# Altium schematic sheet MBoard_RelayStack.SchDoc
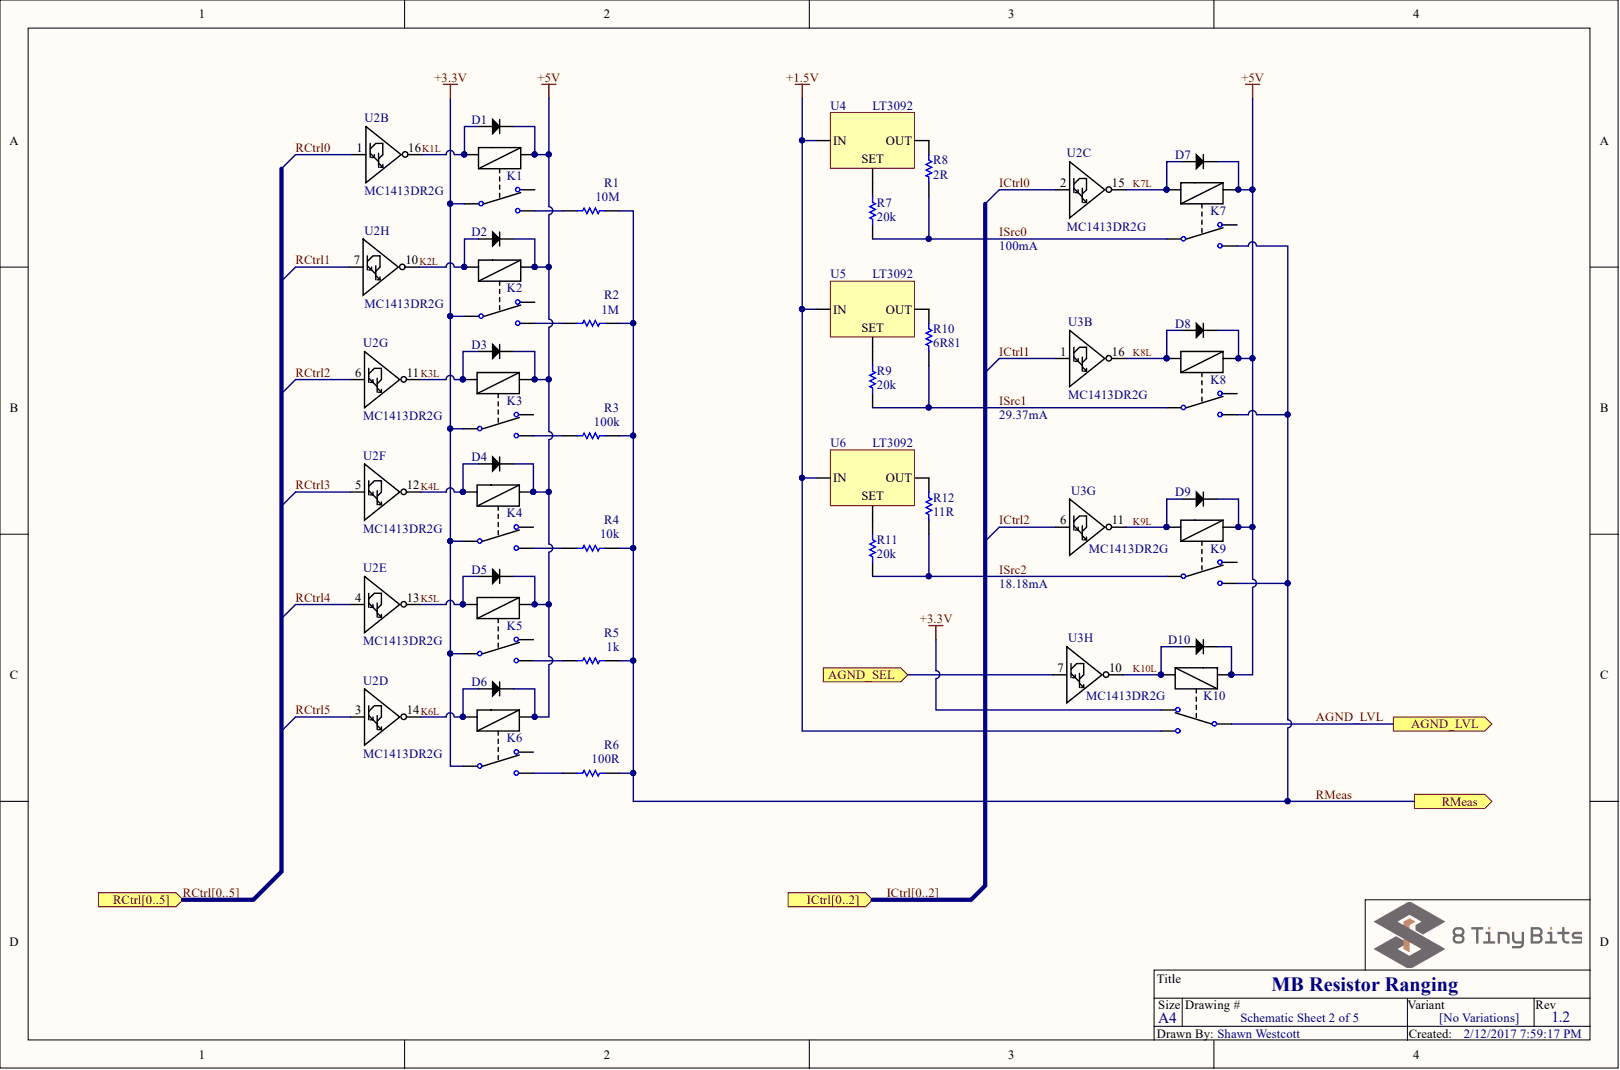
[diagram p0: MBoard_RelayStack.SchDoc]

## Parts
D1  1N4148  [SOD-123]  (value not drawn)
D2  1N4148  [SOD-123]  (value not drawn)
D3  1N4148  [SOD-123]  (value not drawn)
D4  1N4148  [SOD-123]  (value not drawn)
D5  1N4148  [SOD-123]  (value not drawn)
D6  1N4148  [SOD-123]  (value not drawn)
D7  1N4148  [SOD-123]  (value not drawn)
D8  1N4148  [SOD-123]  (value not drawn)
D9  1N4148  [SOD-123]  (value not drawn)
D10  1N4148  [SOD-123]  (value not drawn)
K1  SPDT Relay  [G5V-1-DC5]  (value not drawn)
K2  SPDT Relay  [G5V-1-DC5]  (value not drawn)
K3  SPDT Relay  [G5V-1-DC5]  (value not drawn)
K4  SPDT Relay  [G5V-1-DC5]  (value not drawn)
K5  SPDT Relay  [G5V-1-DC5]  (value not drawn)
K6  SPDT Relay  [G5V-1-DC5]  (value not drawn)
K7  SPDT Relay  [G5V-1-DC5]  (value not drawn)
K8  SPDT Relay  [G5V-1-DC5]  (value not drawn)
K9  SPDT Relay  [G5V-1-DC5]  (value not drawn)
K10  SPDT Relay  [G5V-1-DC5]  (value not drawn)
R1  value 10M  [Res 1206]  (hidden comment: Res1)
R2  value 1M  [Res 1206]  (hidden comment: Res1)
R3  value 100k  [Res 1206]  (hidden comment: Res1)
R4  value 10k  [Res 1206]  (hidden comment: Res1)
R5  value 1k  [Res 1206]  (hidden comment: Res1)
R6  value 100R  [Res 1206]  (hidden comment: Res1)
R7  value 20k  [Axial, 0.6 (1/4W Std)]  (hidden comment: Res1)
R8  value 2R  [Axial, 0.6 (1/4W Std)]  (hidden comment: Res1)
R9  value 20k  [Axial, 0.6 (1/4W Std)]  (hidden comment: Res1)
R10  value 6R81  [Axial, 0.6 (1/4W Std)]  (hidden comment: Res1)
R11  value 20k  [Axial, 0.6 (1/4W Std)]  (hidden comment: Res1)
R12  value 11R  [Axial, 0.6 (1/4W Std)]  (hidden comment: Res1)
U2  MC1413DR2G  [16-SOIC]  (parts B,C,D,E,F,G,H)
U3  MC1413DR2G  [16-SOIC]  (parts B,G,H)
U4  LT3092  [LT-3092 SOT-223]
U5  LT3092  [LT-3092 SOT-223]
U6  LT3092  [LT-3092 SOT-223]

## Ports
AGND_LVL, AGND_SEL, ICTRL0, ICTRL1, ICTRL2, ICTRL[0..2], RCTRL0, RCTRL1, RCTRL2, RCTRL3, RCTRL4, RCTRL5, RCTRL[0..5], RMEAS

## Nets
+1.5V: K10.B U4.3 U5.3 U6.3
+3.3V: K1.C K2.C K3.C K4.C K5.C K6.C K10.A
+5V: D1.K D2.K D3.K D4.K D5.K D6.K D7.K D8.K D9.K D10.K K1.L2 K2.L2 K3.L2 K4.L2 K5.L2 K6.L2 K7.L2 K8.L2 K9.L2 K10.L1
AGND_LVL: K10.C ; port AGND_LVL
AGND_SEL: U3.7 ; port AGND_SEL
ICTRL0: U2.2 ; port ICTRL[0..2]  [also labelled ICTRL]
ICTRL1: U3.1 ; port ICTRL[0..2]  [also labelled ICTRL]
ICTRL2: U3.6 ; port ICTRL[0..2]  [also labelled ICTRL]
ISRC0: K7.C R7.1 R8.1
ISRC1: K8.C R9.1 R10.1
ISRC2: K9.C R11.1 R12.1
K1L: D1.A K1.L1 U2.16
K2L: D2.A K2.L1 U2.10
K3L: D3.A K3.L1 U2.11
K4L: D4.A K4.L1 U2.12
K5L: D5.A K5.L1 U2.13
K6L: D6.A K6.L1 U2.14
K7L: D7.A K7.L1 U2.15
K8L: D8.A K8.L1 U3.16
K9L: D9.A K9.L1 U3.11
K10L: D10.A K10.L2 U3.10
RCTRL0: U2.1 ; port RCTRL[0..5]  [also labelled RCTRL]
RCTRL1: U2.7 ; port RCTRL[0..5]  [also labelled RCTRL]
RCTRL2: U2.6 ; port RCTRL[0..5]  [also labelled RCTRL]
RCTRL3: U2.5 ; port RCTRL[0..5]  [also labelled RCTRL]
RCTRL4: U2.4 ; port RCTRL[0..5]  [also labelled RCTRL]
RCTRL5: U2.3 ; port RCTRL[0..5]  [also labelled RCTRL]
RMEAS: K7.B K8.B K9.B R1.2 R2.2 R3.2 R4.2 R5.2 R6.2 ; port RMEAS
NetK1_B: K1.B R1.1
NetK2_B: K2.B R2.1
NetK3_B: K3.B R3.1
NetK4_B: K4.B R4.1
NetK5_B: K5.B R5.1
NetK6_B: K6.B R6.1
NetR7_2: R7.2 U4.1
NetR8_2: R8.2 U4.2
NetR9_2: R9.2 U5.1
NetR10_2: R10.2 U5.2
NetR11_2: R11.2 U6.1
NetR12_2: R12.2 U6.2

Not connected: K1 A; K2 A; K3 A; K4 A; K5 A; K6 A; K7 A; K8 A; K9 A

## Library data of the parts
D1-D10 = 1N4148: DIODE GEN PURP 75V 300MA SOD323; Mfr1: SMC Diodes; Mfr1 PN: 1N4148WSTR; Component Kind: Standard; Library Name: Custom_8TB.SchLib
K1-K10 = SPDT Relay: SPDT General Purpose Relay; Component Kind: Standard; Library Name: Custom_8TB.SchLib
R1-R12 = Res1: Resistor; Component Kind: Standard; LatestRevisionDate: 17-Jul-2002; LatestRevisionNote: Re-released for DXP Platform.; Library Name: Miscellaneous Devices.IntLib; PackageDescription: Axial Device, Thru-Hole; 2 Leads; 0.3 in Pin Spacing; PackageReference: AXIAL-0.3; Published: 8-Jun-2000; Signal Integrity: Res; Simulation: RESISTOR; Publisher: Altium Limited
U2 U3 = MC1413DR2G: TRANS 7NPN DARL 50V 0.5A 16SO; Mfr1: ON Semi; Mfr1 PN: MC1413DR2GOSCT; Component Kind: Standard; Library Name: Custom_8TB.SchLib
U4-U6 = LT3092EST: IC CURRENT SOURCE 1%; Mfr1: Linear Technology; Mfr1 PN: LT3092EST#PBF; Component Kind: Standard; Library Name: Custom_8TB.SchLib
